# Altium schematic sheet EIT_Timing_ESD.SchDoc
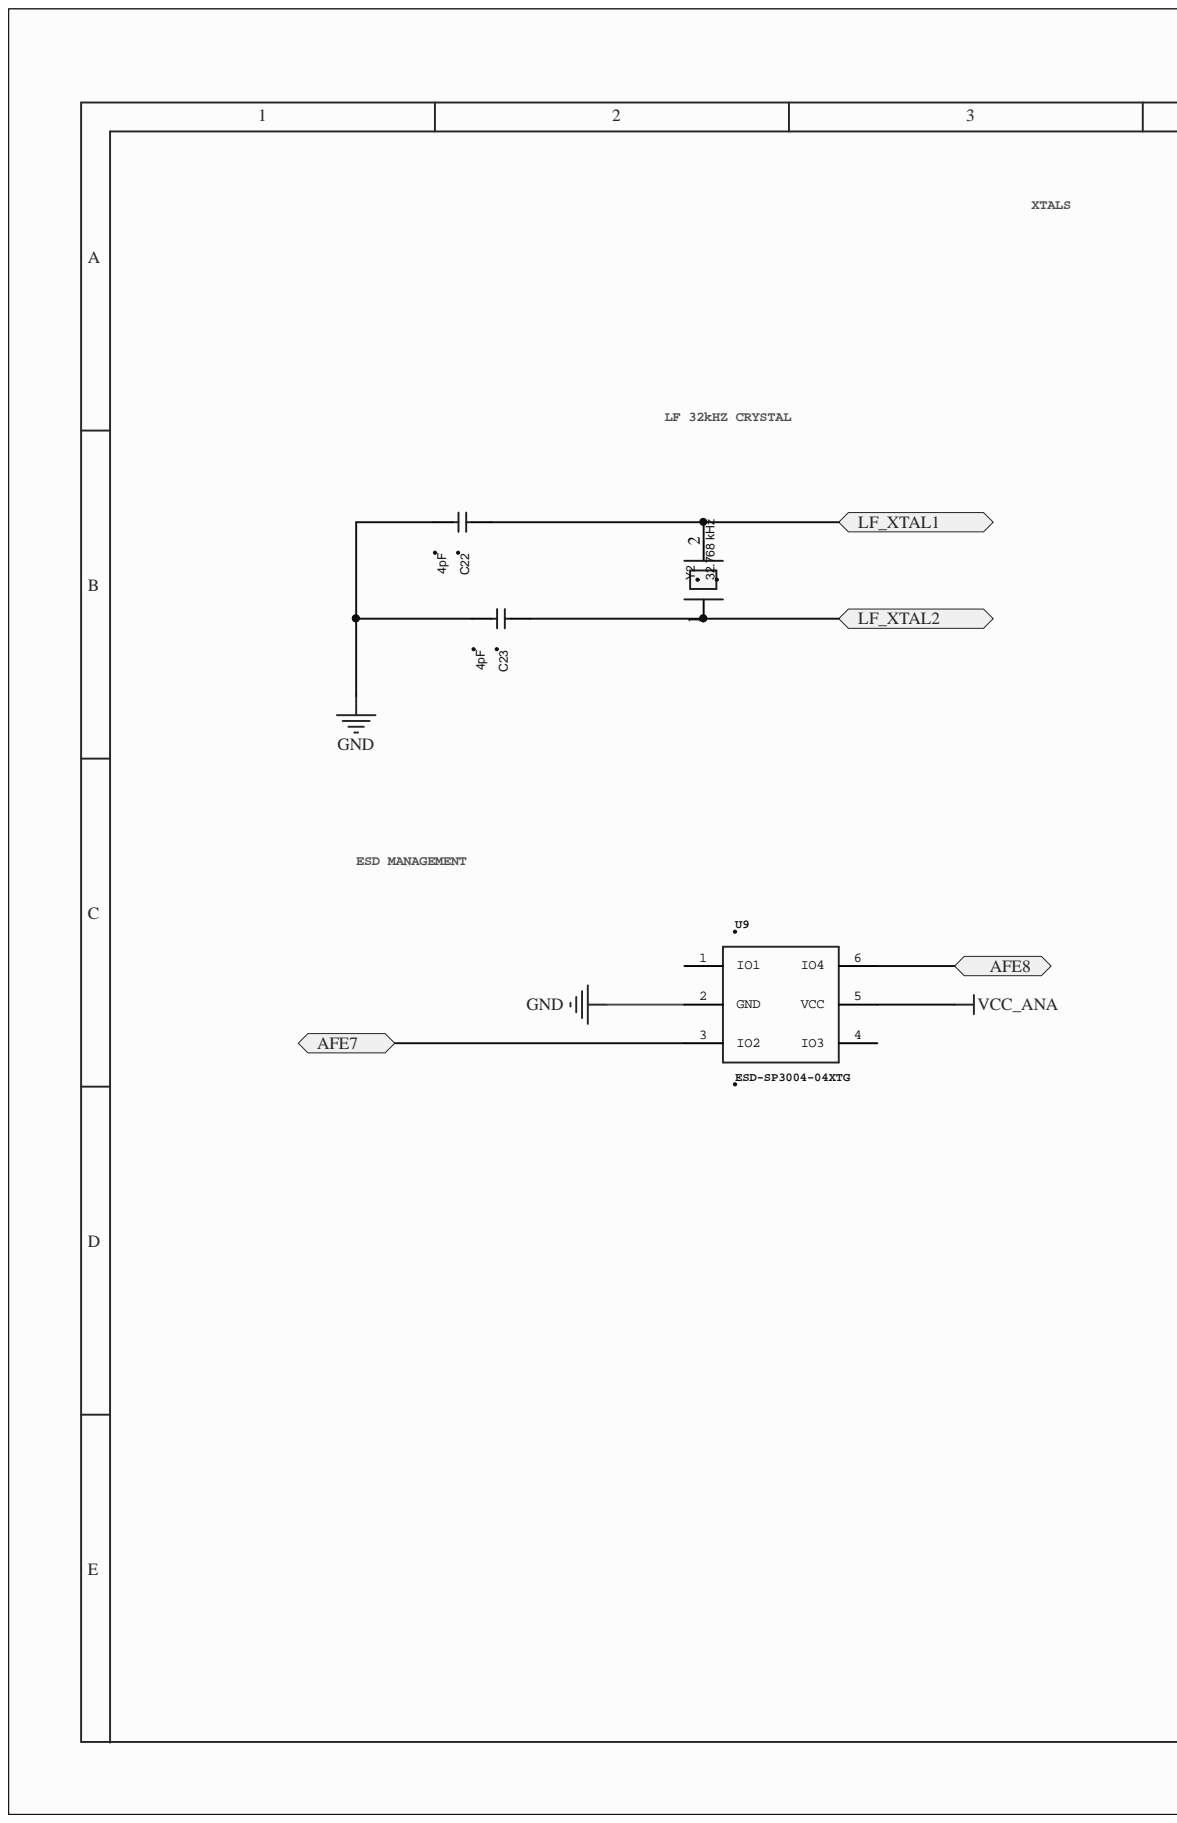
[diagram p0: EIT_Timing_ESD.SchDoc, left]
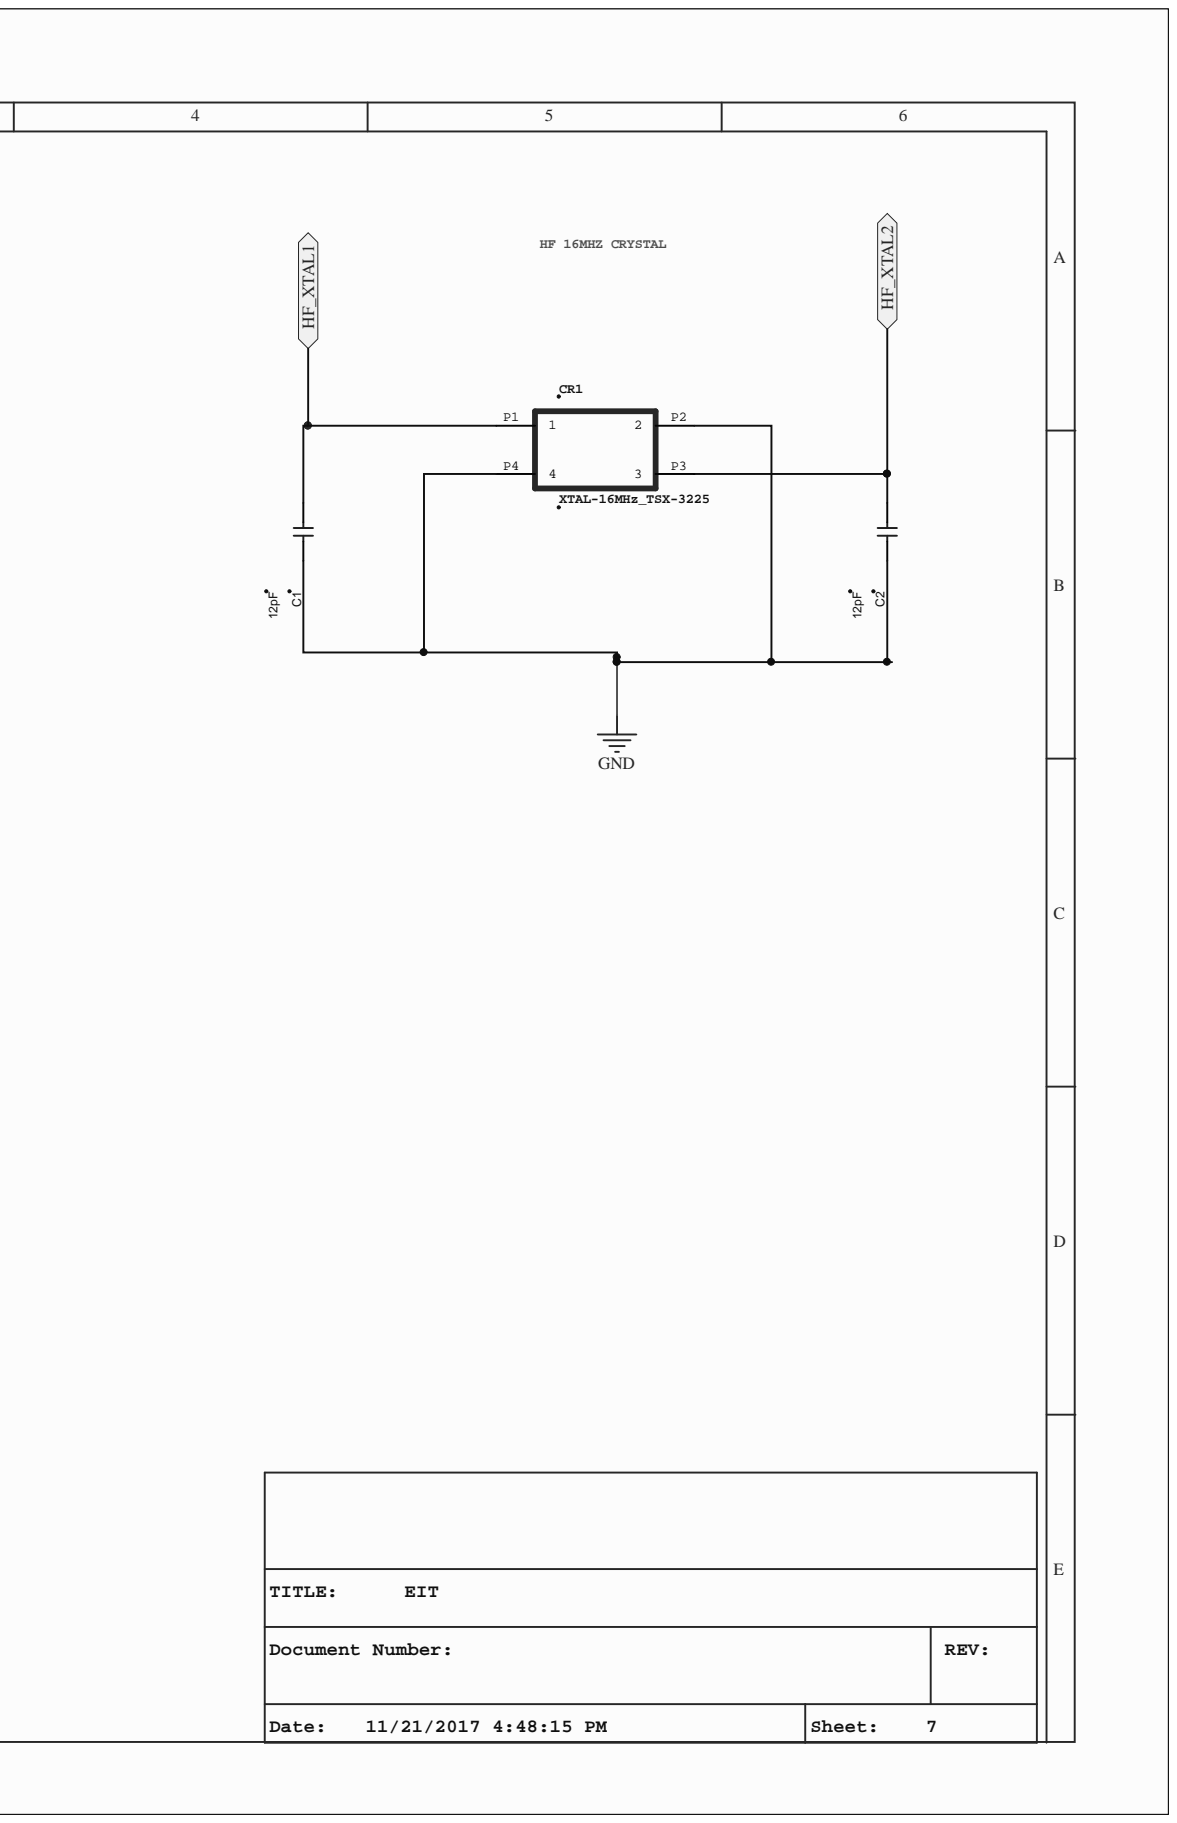
[diagram p1: EIT_Timing_ESD.SchDoc, right]

## Parts
C1  12pF  [CAP 0402/1005]
C2  12pF  [CAP 0402/1005]
C22  4pF  [CAP 0402/1005]
C23  4pF  [CAP 0402/1005]
CR1  XTAL-16MHz_TSX-3225  [TSX-3225]
U9  ESD-SP3004-04XTG  [ESD-SOT563]
Y2  32.768 kHz  [XTAL2-2P0X1P2-SM]

## Ports
AFE7, AFE8, HF_XTAL1, HF_XTAL2, LF_XTAL1, LF_XTAL2

## Nets
GND: C1.1 C2.1 C22.1 C23.1 CR1.P2 CR1.P4 U9.2(GND)
VCC_ANA: U9.5(VCC)
AFE7: U9.3(IO2) ; port AFE7
AFE8: U9.6(IO4) ; port AFE8
HF_XTAL1: C1.2 CR1.P1 ; port HF_XTAL1
HF_XTAL2: C2.2 CR1.P3 ; port HF_XTAL2
LF_XTAL1: C22.2 Y2.2 ; port LF_XTAL1
LF_XTAL2: C23.2 Y2.1 ; port LF_XTAL2

Not connected: U9 1(IO1) 4(IO3)

## Library data of the parts
C1 C2 = CAP_0402_12pF: CAP CER 12PF 100V C0G/NP0 0402; Manufacturer 1: MURATA ELECTRONICS NORTH AMERICA; Manufacturer Part Number 1: GRM1555C2A120JA01D; Vendor: DIGIKEY; VendorPN: 311-1448-1-ND; Component Kind: Standard; Supplier 1: Digi-Key; Supplier Part Number 1: 490-7300-6-ND; Value: 12pF; Library Name: EIT_lib.SchLib
C22 C23 = CAP_0402_4pF: CAP CER 4PF 100V C0G/NP0 0402; Manufacturer 1: SAMSUNG ELETROMECHANICS; Manufacturer Part Number 1: CL05C040CC5NNNC; Vendor: DIGIKEY; Component Kind: Standard; Supplier 1: Digi-Key; Supplier Part Number 1: 1276-6708-6-ND; Value: 4pF; Library Name: EIT_lib.SchLib
CR1 = XTAL-16MHz_TSX-3225: 16MHz ±50ppm Crystal 10pF 80 Ohms 4-SMD, No Lead; Manufacturer 1: Epson; Manufacturer Part Number: FA-238 16.0000MB-K3; DeviceSetName: XTAL-TSX-3225; GateName_1: G$1; Component Kind: Standard; Supplier 1: Digi-Key; Supplier Part Number 1: SER4046CT-ND; SymbolName_1: TSX-3225; Library Name: EIT_lib.SchLib; LibraryName: BiomedicalImagingLibrary
U9 = ESD-SOT563: TVS DIODE 6VWM 15VC SOT563; Manufacturer 1: Little Fuse; GateName_1: G$1; Component Kind: Standard; Manufacturer Part Number 1: SP3004-04XTG; Library Name: EIT_lib.SchLib
Y2 = XTAL_32KHz_2x1.2: XTAL 32.768KHZ 4.0pF 20ppm 2.0mm x 1.2mm SMT; Manufacturer 1: ABRACON CORP; Manufacturer Part Number 1: ABS06-107-32.768KHZ-T; Vendor: DIGIKEY; VendorPN: 535-12373-1-ND; Component Kind: Standard; Supplier 1: Digi-Key; Supplier Part Number 1: 535-12373-1-ND; Value: 32.768 kHz; Library Name: EIT_lib.SchLib
